# Altium schematic sheet Robot1.SchDoc
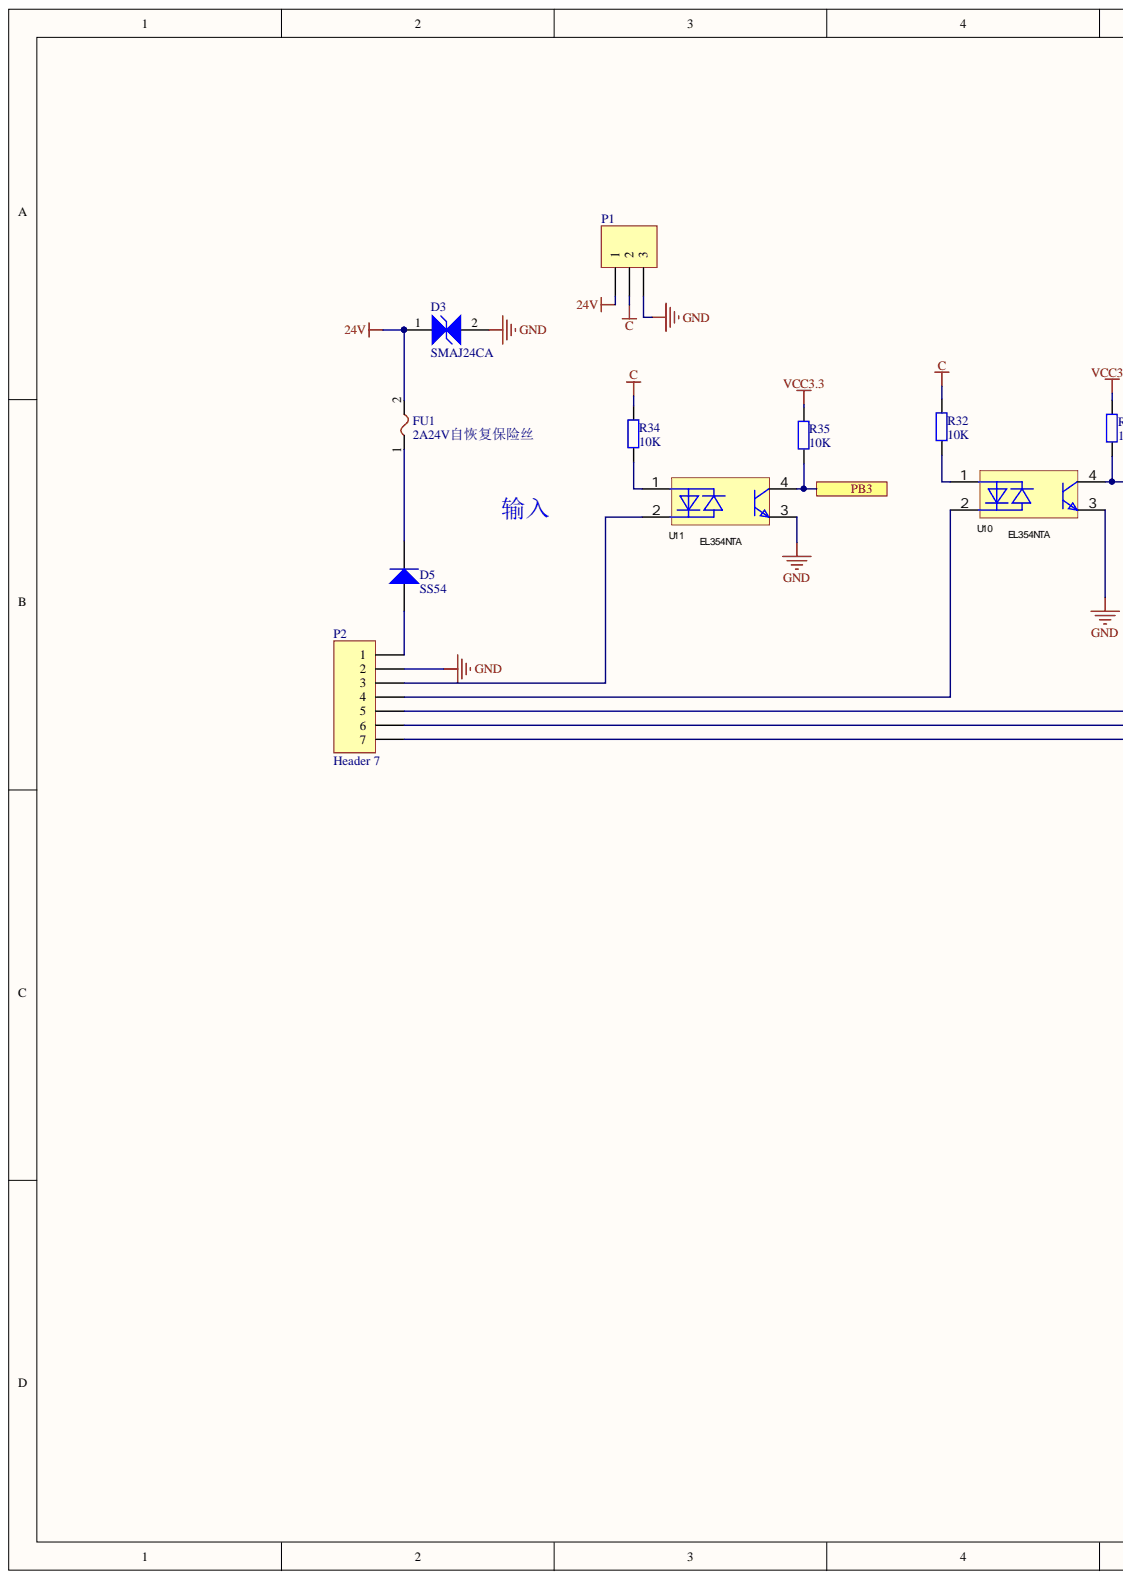
[diagram p0: Robot1.SchDoc, left]
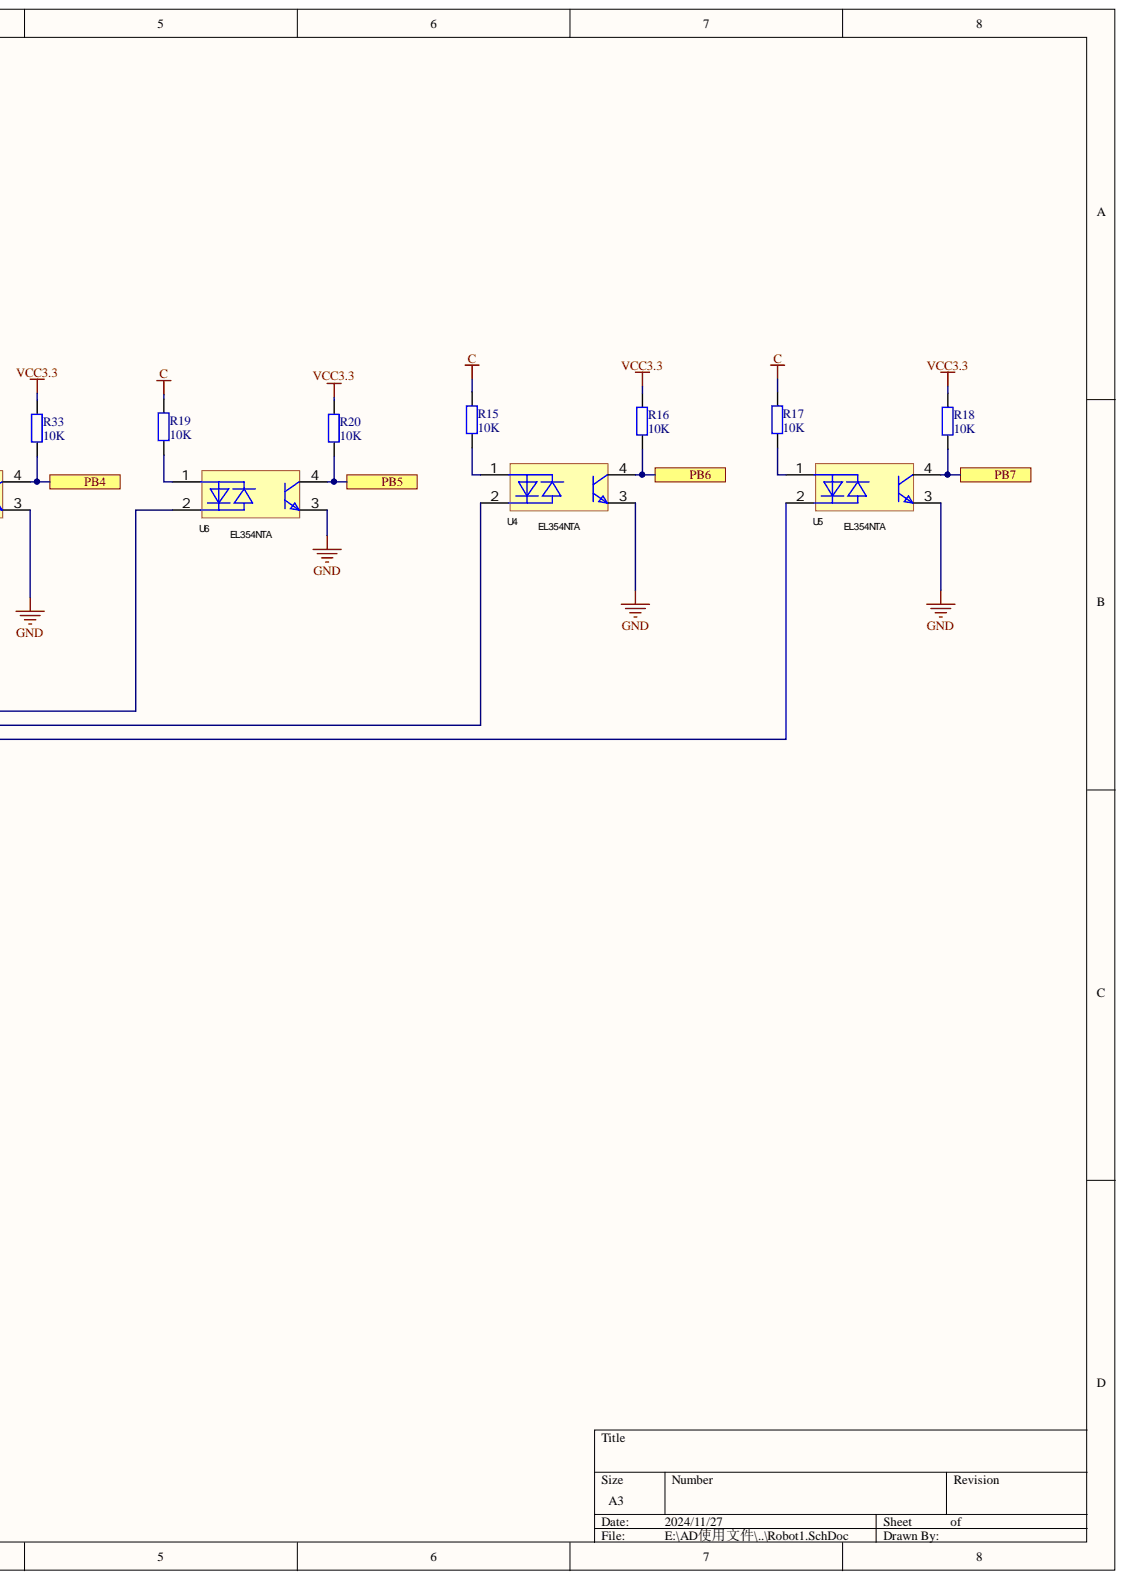
[diagram p1: Robot1.SchDoc, right]

## Parts
D3  SMAJ24CA  [SMA(DO-214AC)_S1]
D5  SS54  [SMA(DO-214AC)_S1]
FU1  2A24V自恢复保险丝  [1812_R]
P1  Header 3  [HDR1X3]  (value not drawn)
P2  Header 7  [3.81-7P -90]
R15  10K  [0603_R]
R16  10K  [0603_R]
R17  10K  [0603_R]
R18  10K  [0603_R]
R19  10K  [0603_R]
R20  10K  [0603_R]
R32  10K  [0603_R]
R33  10K  [0603_R]
R34  10K  [0603_R]
R35  10K  [0603_R]
U4  EL354NTA  [SOP-4_P2.54]
U5  EL354NTA  [SOP-4_P2.54]
U6  EL354NTA  [SOP-4_P2.54]
U10  EL354NTA  [SOP-4_P2.54]
U11  EL354NTA  [SOP-4_P2.54]

## Ports
PB3, PB4, PB5, PB6, PB7

## Nets
24V: D3.1 FU1.2 P1.1
C: P1.2 R15.1 R17.1 R19.1 R32.1 R34.1
GND: D3.2 P1.3 P2.2 U4.3 U5.3 U6.3 U10.3 U11.3
VCC3.3: R16.1 R18.1 R20.1 R33.1 R35.1
PB3: R35.2 U11.4 ; port PB3
PB4: R33.2 U10.4 ; port PB4
PB5: R20.2 U6.4 ; port PB5
PB6: R16.2 U4.4 ; port PB6
PB7: R18.2 U5.4 ; port PB7
NetD5_1: D5.1 P2.1
NetD5_2: D5.2 FU1.1
NetP2_3: P2.3 U11.2
NetP2_4: P2.4 U10.2
NetP2_5: P2.5 U6.2
NetP2_6: P2.6 U4.2
NetP2_7: P2.7 U5.2
NetR15_2: R15.2 U4.1
NetR17_2: R17.2 U5.1
NetR19_2: R19.2 U6.1
NetR32_2: R32.2 U10.1
NetR34_2: R34.2 U11.1

## Library data of the parts
D3 = SMB/DO-214AA P6SMB6.8CA: TVS; Component Kind: Standard; ComponentLink1Description: 供应商链接; ComponentLink1URL: http://www.szlcsc.com/product/details_79530.html; Library Name: Diode.SchLib; Package: SMB/DO-214AA; Supplier: LC; SuppliersPartNumber: C78395
D5 = SOD-123 B5819W  SL: 肖特基二极管; Component Kind: Standard; ComponentLink1Description: 供应商链接; ComponentLink1URL: http://www.szlcsc.com/product/details_9093.html; Library Name: Diode.SchLib; Package: SOD-123; Supplier: LC; SuppliersPartNumber: C8598
FU1 = 自恢复保险丝: Component Kind: Standard; Library Name: wgh元件库.SCHLIB
P1 = Header 3: Header, 3-Pin; Component Kind: Standard; LatestRevisionDate: 17-Jul-2002; LatestRevisionNote: Re-released for DXP Platform.; Library Name: Miscellaneous Connectors.IntLib; Publisher: Altium Limited
P2 = Header 7: Header, 7-Pin; Component Kind: Standard; LatestRevisionDate: 17-Jul-2002; LatestRevisionNote: Re-released for DXP Platform.; Library Name: Miscellaneous Connectors.IntLib; Publisher: Altium Limited
R15-R20 R32-R35 = R: Component Kind: Standard; Library Name: Ling0709.SchLib
U4-U6 U10 U11 = EL354NTA: Manufacturer: 国芯佳品; Add into BOM: yes; Collector-Emitter Saturation Voltage (Vce(sat)@Ic,IF): 100mV@1mA,20mA; Device: GX357NC; Fall time: 4us@2mA,100Ω; Forward Voltage: 1.2V; Input voltage type: DC; Number of Outputs: 1; Output Type: 光电三极管; Receiving End Voltage: 80V; Reverse Voltage: 6V; Rise time: 3us@2mA,100Ω; Component Kind: Standard; Convert to PCB: yes; Datasheet: https://atta.szlcsc.com/upload/public/pdf/source/20210129/C2684626_4A59DA448D21027D2E78B78F588D2B7F.pdf; JLCPCB Part Class: Extended Part; LCSC Part Name: DC输入; Manufacturer Part: GX357NC; Name: GX357NC; Operating Temperature: -55℃~+100℃; Output Current: -; PCB3D: SOP-4_L4.0-W4.4-H2.1-LS7.0-P2.54; Supplier: LCSC; Supplier Footprint: SOP-4-2.54mm; Supplier Part: C2684626; Symbol: GX357NC; Library Name: LDGlib.SchLib
